# Altium schematic sheet Navigation_Controll.SchDoc(Navigation_Controll)
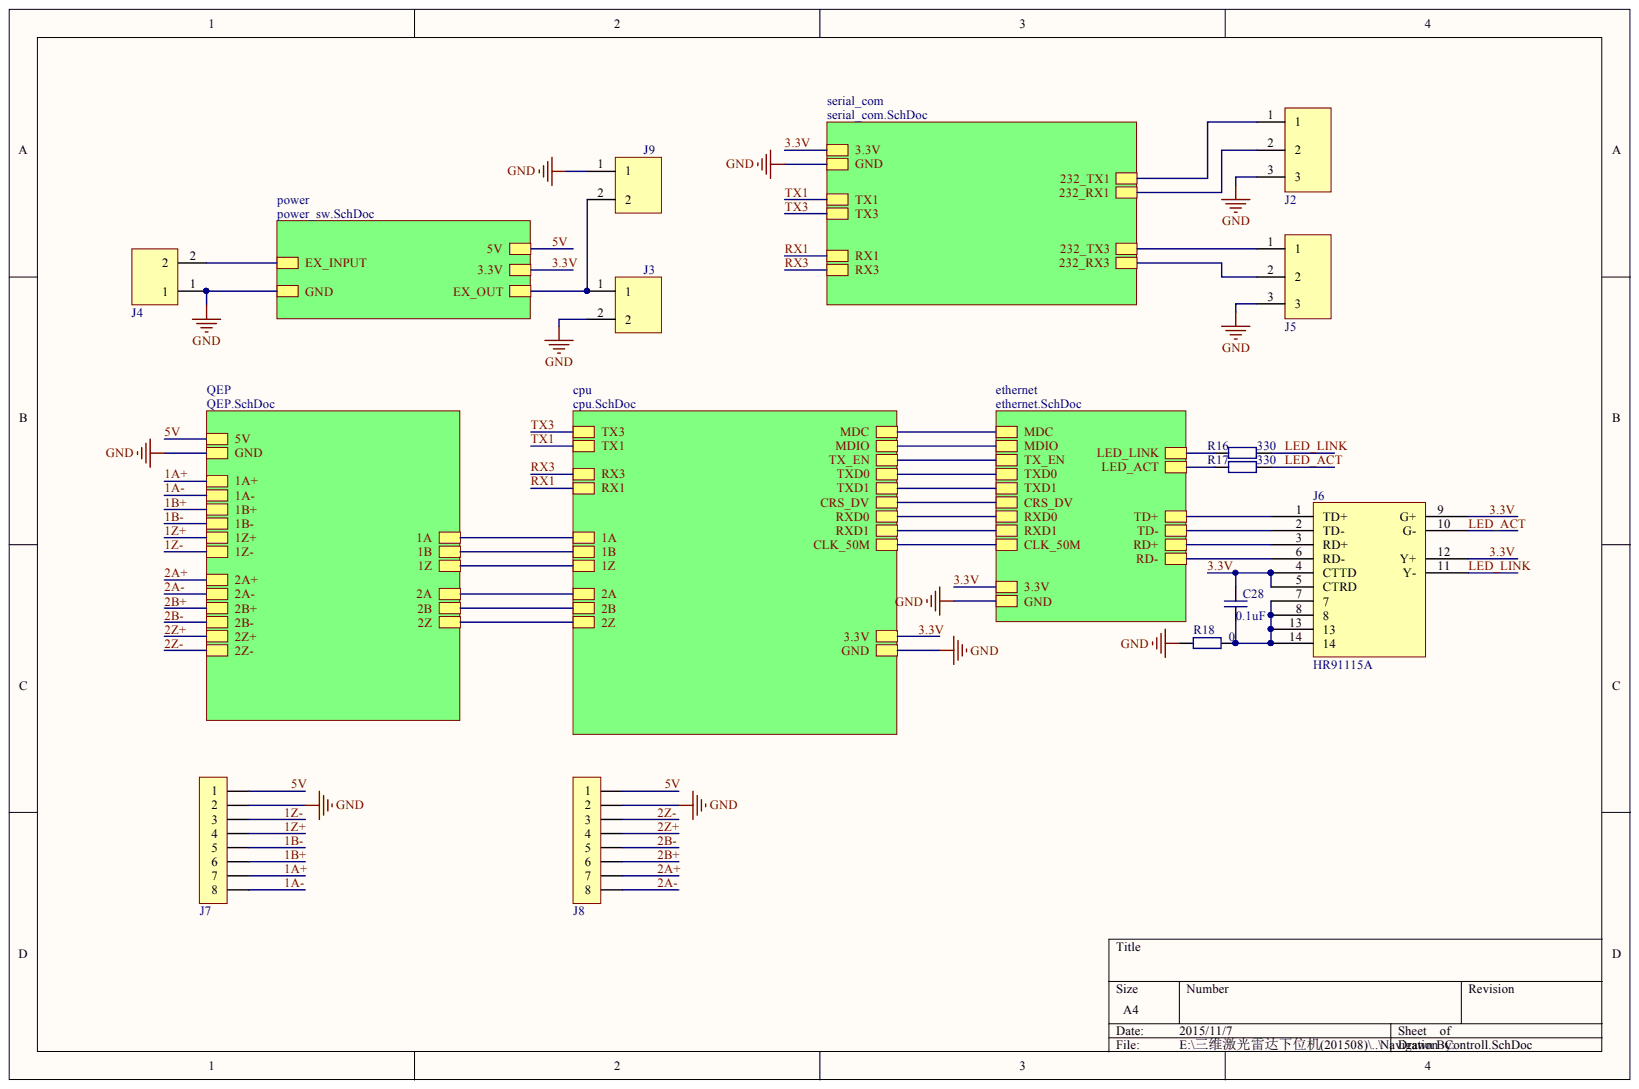
[diagram p0: Navigation_Controll.SchDoc]

## Parts
C28  value 0.1uF  [CAP-0805]  (hidden comment: CAP)
J2  DG3P  [DG381-3P]  (value not drawn)
J3  DG2P  [DG381-2P]  (value not drawn)
J4  DG2P  [DG508-2P]  (value not drawn)
J5  DG3P  [DG381-3P]  (value not drawn)
J6  HR91115A  [RJ45_ISO]
J7  HDR1*8  [KF128L-8P]  (value not drawn)
J8  HDR1*8  [KF128L-8P]  (value not drawn)
J9  DG2P  [DG381-2P]  (value not drawn)
R16  value 330  [RES-0805]  (hidden comment: RES)
R17  value 330  [RES-0805]  (hidden comment: RES)
R18  value 0  [RES-0805]  (hidden comment: RES)

## Nets
GND: J2.3 J3.2 J4.1 J5.3 J7.2 J8.2 J9.1 R18.1
1A+: J7.7
1A-: J7.8
1B+: J7.6
1B-: J7.5
1Z+: J7.4
1Z-: J7.3
2A+: J8.7
2A-: J8.8
2B+: J8.6
2B-: J8.5
2Z+: J8.4
2Z-: J8.3
3.3V: C28.2 J6.4(CTTD) J6.5(CTRD) J6.9(G+) J6.12(Y+)
5V: J7.1 J8.1
LED_ACT: J6.10(G-) R17.2
LED_LINK: J6.11(Y-) R16.2
NetC28_1: C28.1 J6.7 J6.8 J6.13 J6.14 R18.2
NetJ2_1: J2.1
NetJ2_2: J2.2
NetJ3_1: J3.1 J9.2
NetJ4_2: J4.2
NetJ5_1: J5.1
NetJ5_2: J5.2
NetJ6_1: J6.1(TD+)
NetJ6_2: J6.2(TD-)
NetJ6_3: J6.3(RD+)
NetJ6_6: J6.6(RD-)
NetR16_1: R16.1
NetR17_1: R17.1
1A:
1B:
1Z:
2A:
2B:
2Z:
CLK_50M:
CRS_DV:
MDC:
MDIO:
RX1:
RX3:
RXD0:
RXD1:
TX1:
TX3:
TX_EN:
TXD0:
TXD1:

## Library data of the parts
J6 = NET_PORT: Component Kind: Standard; Library Name: Schlib1.SchLib
C28 = CAP: Component Kind: Standard; Library Name: Schlib1.SchLib
J2 J5 = DG3P: Component Kind: Standard; Library Name: Schlib1.SchLib
J3 J4 J9 = DG2P: Component Kind: Standard; Library Name: Schlib1.SchLib
J7 J8 = HDR1*8: Component Kind: Standard; Library Name: Schlib1.SchLib
R16-R18 = RES: Component Kind: Standard; Library Name: Schlib1.SchLib
